# Altium schematic sheet AD936X.SchDoc
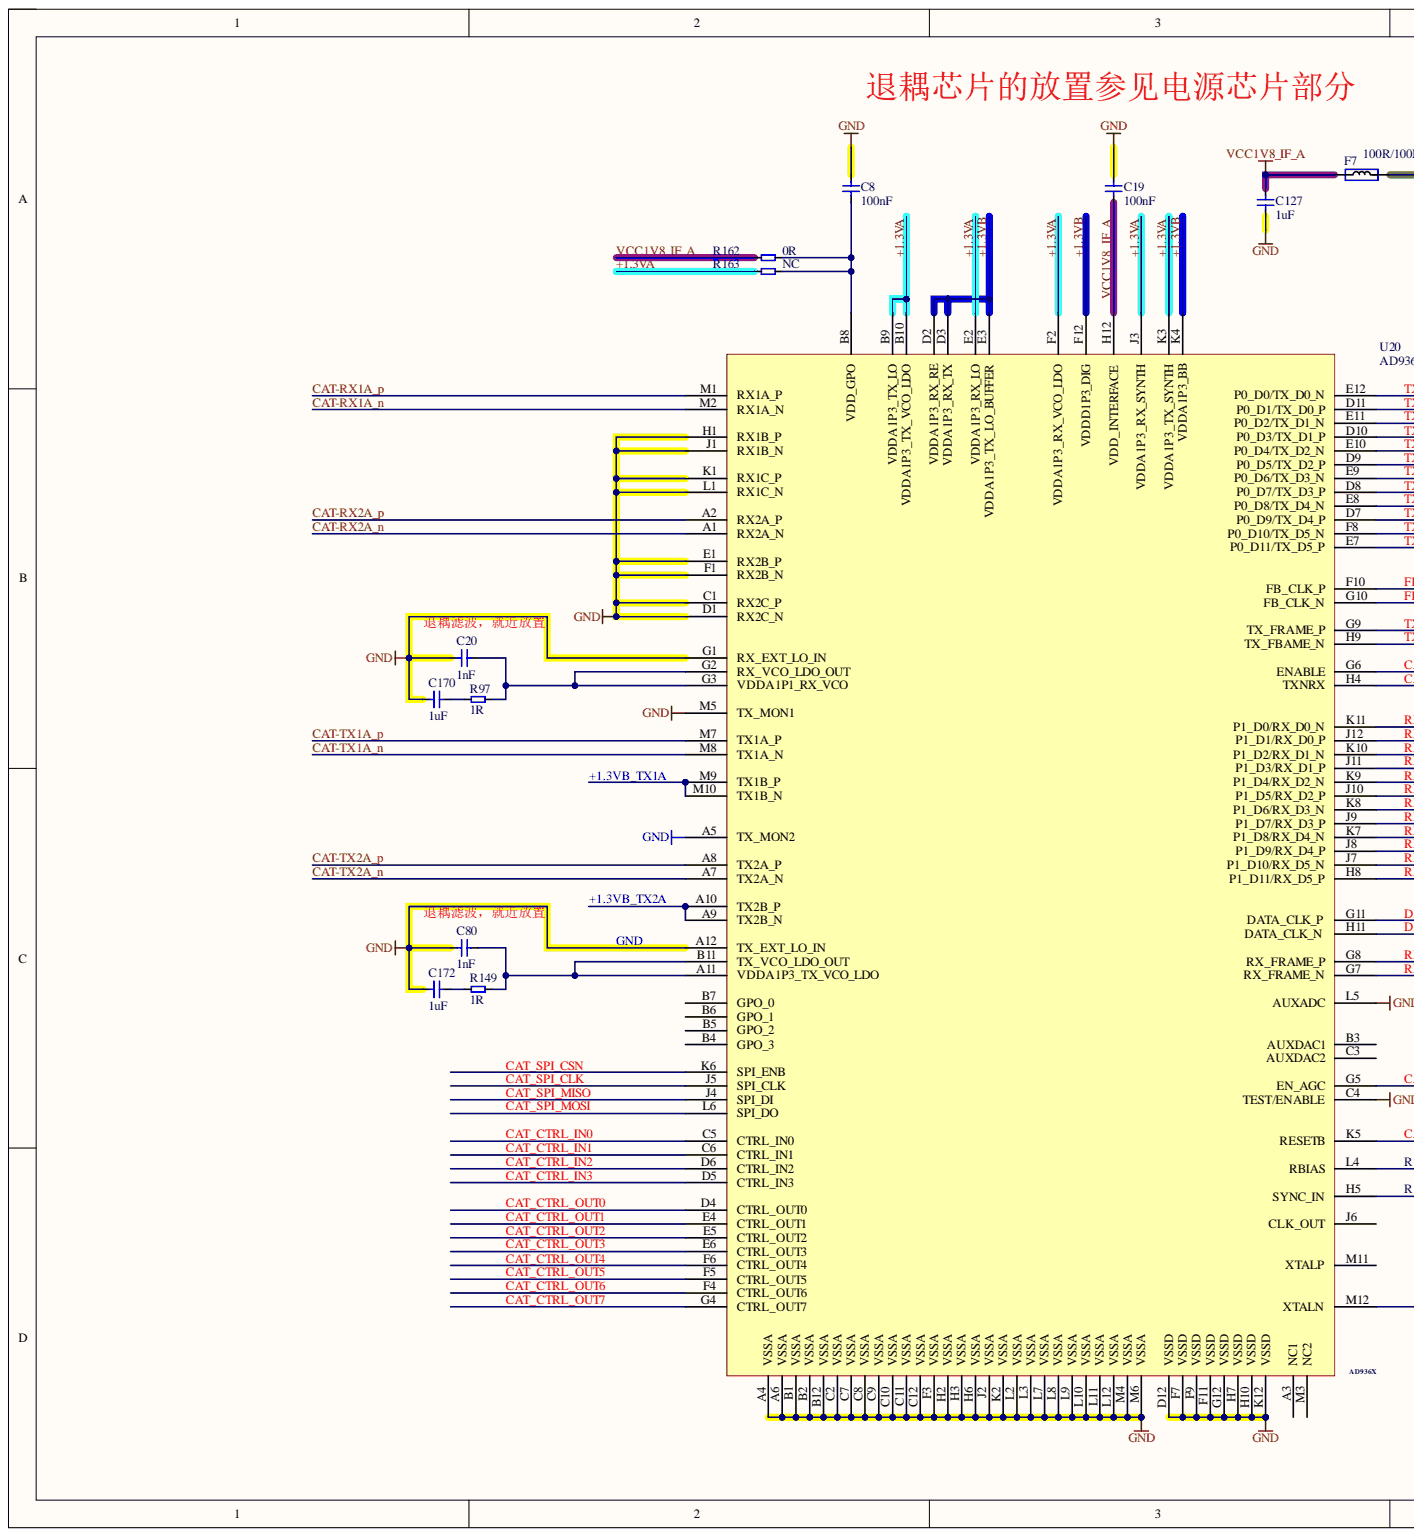
[diagram p0: AD936X.SchDoc, left]
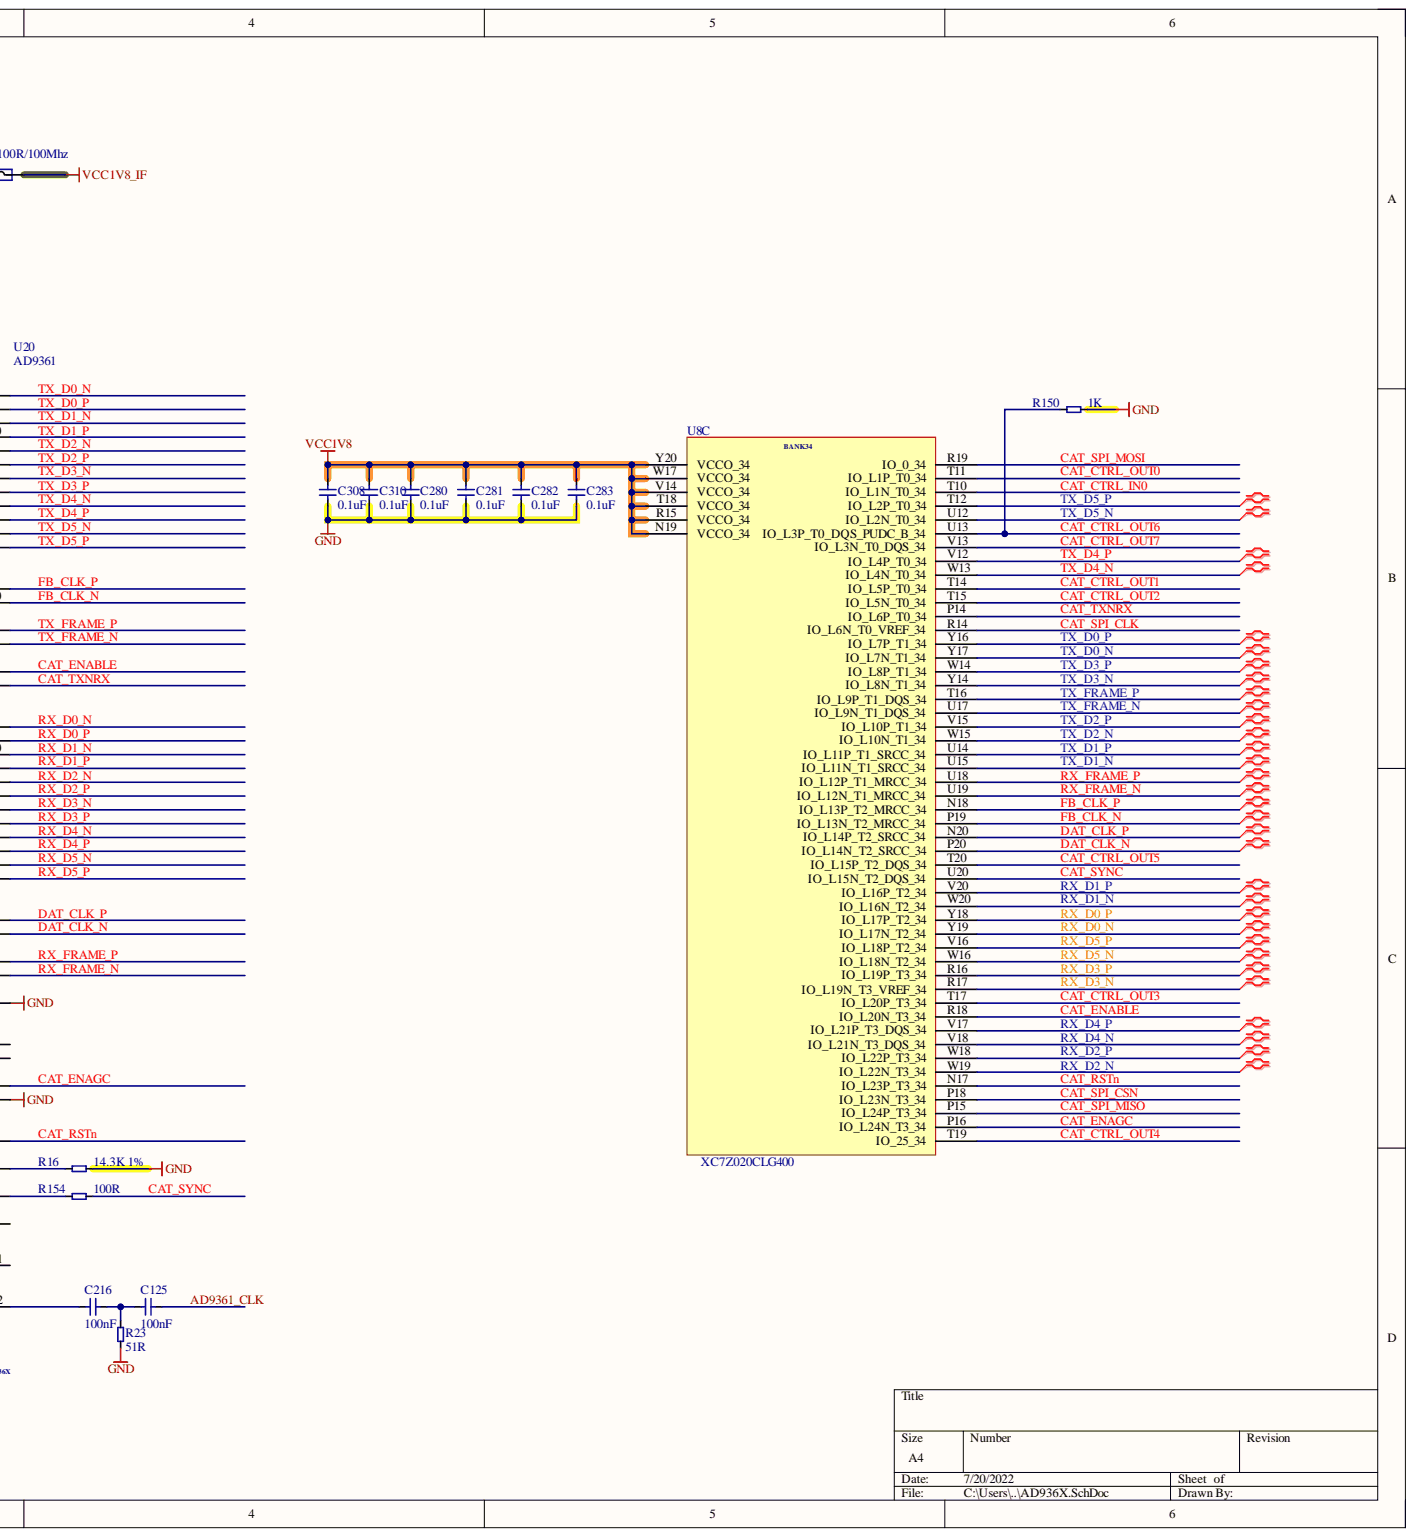
[diagram p1: AD936X.SchDoc, right]

## Parts
C8  100nF  [C0201]
C19  100nF  [C0201]
C20  1nF  [C0201]
C80  1nF  [C0201]
C125  100nF  [C0201]
C127  1uF  [C0402]
C170  1uF  [C0402]
C172  1uF  [C0402]
C216  100nF  [C0201]
C280  0.1uF  [C0201]
C281  0.1uF  [C0201]
C282  0.1uF  [C0201]
C283  0.1uF  [C0201]
C308  0.1uF  [C0201]
C310  0.1uF  [C0201]
F7  100R/100Mhz  [L0402]
R16  14.3K 1%  [R0201]
R23  51R  [R0201]
R97  1R  [R0201]
R149  1R  [R0201]
R150  1K  [R0201]
R154  100R  [R0201]
R162  0R  [R0201]
R163  NC  [R0201]
U8  XC7Z020CLG400  [MY_CLG400]  (part C)
U20  AD9361  [BGA144]

## Nets
VCC1V8: C280.1 C281.1 C282.1 C283.1 C308.1 C310.1 U8.N19(VCCO_34) U8.R15(VCCO_34) U8.T18(VCCO_34) U8.V14(VCCO_34) U8.W17(VCCO_34) U8.Y20(VCCO_34)
VCC1V8_IF: F7.2
+1.3VA: R163.1 U20.B9(VDDA1P3_TX_LO) U20.B10(VDDA1P3_TX_VCO_LDO) U20.E2(VDDA1P3_RX_LO) U20.F2(VDDA1P3_RX_VCO_LDO) U20.J3(VDDA1P3_RX_SYNTH) U20.K3(VDDA1P3_TX_SYNTH)
+1.3VB: U20.D2(VDDA1P3_RX_RE) U20.D3(VDDA1P3_RX_TX) U20.E3(VDDA1P3_TX_LO_BUFFER) U20.F12(VDDD1P3_DIG) U20.K4(VDDA1P3_BB)
+1.3VB_TX1A: U20.M9(TX1B_P) U20.M10(TX1B_N)
+1.3VB_TX2A: U20.A9(TX2B_N) U20.A10(TX2B_P)
AD9361_CLK: C125.1
CAT-RX1A_n: U20.M2(RX1A_N)
CAT-RX1A_p: U20.M1(RX1A_P)
CAT-RX2A_n: U20.A1(RX2A_N)
CAT-RX2A_p: U20.A2(RX2A_P)
CAT-TX1A_n: U20.M8(TX1A_N)
CAT-TX1A_p: U20.M7(TX1A_P)
CAT-TX2A_n: U20.A7(TX2A_N)
CAT-TX2A_p: U20.A8(TX2A_P)
CAT_CTRL_IN0: U8.T10(IO_L1N_T0_34) U20.C5(CTRL_IN0)
CAT_CTRL_IN1: U20.C6(CTRL_IN1)
CAT_CTRL_IN2: U20.D6(CTRL_IN2)
CAT_CTRL_IN3: U20.D5(CTRL_IN3)
CAT_CTRL_OUT0: U8.T11(IO_L1P_T0_34) U20.D4(CTRL_OUT0)
CAT_CTRL_OUT1: U8.T14(IO_L5P_T0_34) U20.E4(CTRL_OUT1)
CAT_CTRL_OUT2: U8.T15(IO_L5N_T0_34) U20.E5(CTRL_OUT2)
CAT_CTRL_OUT3: U8.T17(IO_L20P_T3_34) U20.E6(CTRL_OUT3)
CAT_CTRL_OUT4: U8.T19(IO_25_34) U20.F6(CTRL_OUT4)
CAT_CTRL_OUT5: U8.T20(IO_L15P_T2_DQS_34) U20.F5(CTRL_OUT5)
CAT_CTRL_OUT6: R150.1 U8.U13(IO_L3P_T0_DQS_PUDC_B_34) U20.F4(CTRL_OUT6)
CAT_CTRL_OUT7: U8.V13(IO_L3N_T0_DQS_34) U20.G4(CTRL_OUT7)
CAT_ENABLE: U8.R18(IO_L20N_T3_34) U20.G6(ENABLE)
CAT_ENAGC: U8.P16(IO_L24N_T3_34) U20.G5(EN_AGC)
CAT_RSTn: U8.N17(IO_L23P_T3_34) U20.K5(RESETB)
CAT_SPI_CLK: U8.R14(IO_L6N_T0_VREF_34) U20.J5(SPI_CLK)
CAT_SPI_CSN: U8.P18(IO_L23N_T3_34) U20.K6(SPI_ENB)
CAT_SPI_MISO: U8.P15(IO_L24P_T3_34) U20.J4(SPI_DI)
CAT_SPI_MOSI: U8.R19(IO_0_34) U20.L6(SPI_DO)
CAT_SYNC: R154.2 U8.U20(IO_L15N_T2_DQS_34)
CAT_TXNRX: U8.P14(IO_L6P_T0_34) U20.H4(TXNRX)
DAT_CLK_N: U8.P20(IO_L14N_T2_SRCC_34) U20.H11(DATA_CLK_N)
DAT_CLK_P: U8.N20(IO_L14P_T2_SRCC_34) U20.G11(DATA_CLK_P)
FB_CLK_N: U8.P19(IO_L13N_T2_MRCC_34) U20.G10(FB_CLK_N)
FB_CLK_P: U8.N18(IO_L13P_T2_MRCC_34) U20.F10(FB_CLK_P)
GND: C8.2 C19.2 C20.1 C80.1 C127.1 C170.1 C172.1 C280.2 C281.2 C282.2 C283.2 C308.2 C310.2 R16.2 R23.1 R150.2 U20.A4(VSSA) U20.A5(TX_MON2) U20.A6(VSSA) U20.A12(TX_EXT_LO_IN) U20.B1(VSSA) U20.B2(VSSA) U20.B12(VSSA) U20.C1(RX2C_P) U20.C2(VSSA) U20.C4(TEST/ENABLE) U20.C7(VSSA) U20.C8(VSSA) U20.C9(VSSA) U20.C10(VSSA) U20.C11(VSSA) U20.C12(VSSA) U20.D1(RX2C_N) U20.D12(VSSD) U20.E1(RX2B_P) U20.F1(RX2B_N) U20.F3(VSSA) U20.F7(VSSD) U20.F9(VSSD) U20.F11(VSSD) U20.G1(RX_EXT_LO_IN) U20.G12(VSSD) U20.H1(RX1B_P) U20.H2(VSSA) U20.H3(VSSA) U20.H6(VSSA) U20.H7(VSSD) U20.H10(VSSD) U20.J1(RX1B_N) U20.J2(VSSA) U20.K1(RX1C_P) U20.K2(VSSA) U20.K12(VSSD) U20.L1(RX1C_N) U20.L2(VSSA) U20.L3(VSSA) U20.L5(AUXADC) U20.L7(VSSA) U20.L8(VSSA) U20.L9(VSSA) U20.L10(VSSA) U20.L11(VSSA) U20.L12(VSSA) U20.M4(VSSA) U20.M5(TX_MON1) U20.M6(VSSA)
RX_D0_N: U8.Y19(IO_L17N_T2_34) U20.K11(P1_D0/RX_D0_N)
RX_D0_P: U8.Y18(IO_L17P_T2_34) U20.J12(P1_D1/RX_D0_P)
RX_D1_N: U8.W20(IO_L16N_T2_34) U20.K10(P1_D2/RX_D1_N)
RX_D1_P: U8.V20(IO_L16P_T2_34) U20.J11(P1_D3/RX_D1_P)
RX_D2_N: U8.W19(IO_L22N_T3_34) U20.K9(P1_D4/RX_D2_N)
RX_D2_P: U8.W18(IO_L22P_T3_34) U20.J10(P1_D5/RX_D2_P)
RX_D3_N: U8.R17(IO_L19N_T3_VREF_34) U20.K8(P1_D6/RX_D3_N)
RX_D3_P: U8.R16(IO_L19P_T3_34) U20.J9(P1_D7/RX_D3_P)
RX_D4_N: U8.V18(IO_L21N_T3_DQS_34) U20.K7(P1_D8/RX_D4_N)
RX_D4_P: U8.V17(IO_L21P_T3_DQS_34) U20.J8(P1_D9/RX_D4_P)
RX_D5_N: U8.W16(IO_L18N_T2_34) U20.J7(P1_D10/RX_D5_N)
RX_D5_P: U8.V16(IO_L18P_T2_34) U20.H8(P1_D11/RX_D5_P)
RX_FRAME_N: U8.U19(IO_L12N_T1_MRCC_34) U20.G7(RX_FRAME_N)
RX_FRAME_P: U8.U18(IO_L12P_T1_MRCC_34) U20.G8(RX_FRAME_P)
TX_D0_N: U8.Y17(IO_L7N_T1_34) U20.E12(P0_D0/TX_D0_N)
TX_D0_P: U8.Y16(IO_L7P_T1_34) U20.D11(P0_D1/TX_D0_P)
TX_D1_N: U8.U15(IO_L11N_T1_SRCC_34) U20.E11(P0_D2/TX_D1_N)
TX_D1_P: U8.U14(IO_L11P_T1_SRCC_34) U20.D10(P0_D3/TX_D1_P)
TX_D2_N: U8.W15(IO_L10N_T1_34) U20.E10(P0_D4/TX_D2_N)
TX_D2_P: U8.V15(IO_L10P_T1_34) U20.D9(P0_D5/TX_D2_P)
TX_D3_N: U8.Y14(IO_L8N_T1_34) U20.E9(P0_D6/TX_D3_N)
TX_D3_P: U8.W14(IO_L8P_T1_34) U20.D8(P0_D7/TX_D3_P)
TX_D4_N: U8.W13(IO_L4N_T0_34) U20.E8(P0_D8/TX_D4_N)
TX_D4_P: U8.V12(IO_L4P_T0_34) U20.D7(P0_D9/TX_D4_P)
TX_D5_N: U8.U12(IO_L2N_T0_34) U20.F8(P0_D10/TX_D5_N)
TX_D5_P: U8.T12(IO_L2P_T0_34) U20.E7(P0_D11/TX_D5_P)
TX_FRAME_N: U8.U17(IO_L9N_T1_DQS_34) U20.H9(TX_FBAME_N)
TX_FRAME_P: U8.T16(IO_L9P_T1_DQS_34) U20.G9(TX_FRAME_P)
VCC1V8_IF_A: C19.1 C127.2 F7.1 R162.1 U20.H12(VDD_INTERFACE)
NetC8_1: C8.1 R162.2 R163.2 U20.B8(VDD_GPO)
NetC20_2: C20.2 R97.2 U20.G2(RX_VCO_LDO_OUT) U20.G3(VDDA1P1_RX_VCO)
NetC80_2: C80.2 R149.2 U20.A11(VDDA1P3_TX_VCO_LDO) U20.B11(TX_VCO_LDO_OUT)
NetC125_2: C125.2 C216.2 R23.2
NetC170_2: C170.2 R97.1
NetC172_2: C172.2 R149.1
NetC216_1: C216.1 U20.M12(XTALN)
NetR16_1: R16.1 U20.L4(RBIAS)
NetR154_1: R154.1 U20.H5(SYNC_IN)

Not connected: U20 A3(NC1) B3(AUXDAC1) B4(GPO_3) B5(GPO_2) B6(GPO_1) B7(GPO_0) C3(AUXDAC2) J6(CLK_OUT) M3(NC2) M11(XTALP)

## Library data of the parts
C8 C19 C20 C80 C125 C127 C170 C172 C216 C280-C283 C308 C310 = C: Capacitor; Component Kind: Standard; Library Name: 电容-SCH.LIB
F7 = Ferrite: Manufacturer: TDK Corporation; Manufacturer Part Number 1: MPZ2012S601AT000; Part Description: FERRITE BEAD 600 OHM 0805 1LN; Current Rating: 2A; DC Resistance (DCR): 100 mOhm Max; Filter Type: Differential Mode - Single; Impedance @ Frequency: 600 Ohm @ 100MHz; Value: 600R, 2A; Category: Filters; Component Kind: Standard; Height (Max): 0.041" (1.05mm); Mounting Type: Surface Mount; Package / Case: 0805 (2012 Metric); Packaging: Tape & Reel (TR); RoHS: RoHS Compliant; Size / Dimension: 0.079" L x 0.049" W (2.00mm x 1.25mm); Supplier 1: Digi-Key; Supplier Part Number 1: 445-2206-2-ND; Library Name: LimeMicroAltiumLib_schLib.SchLib
R16 R23 R97 R149 R150 R154 R162 R163 = RES2: Component Kind: Standard; Library Name: 电阻-SCH.LIB
U20 = AD936X: Component Kind: Standard; Library Name: Schlib1.SchLib
U8 = XC7Z020CLG400: Component Kind: Standard; Library Name: Schlib1.SchLib
